# Altium schematic sheet RECEIVER DJI FPV MAIN.SchDoc("RECEIVER DJI FPV MAIN")
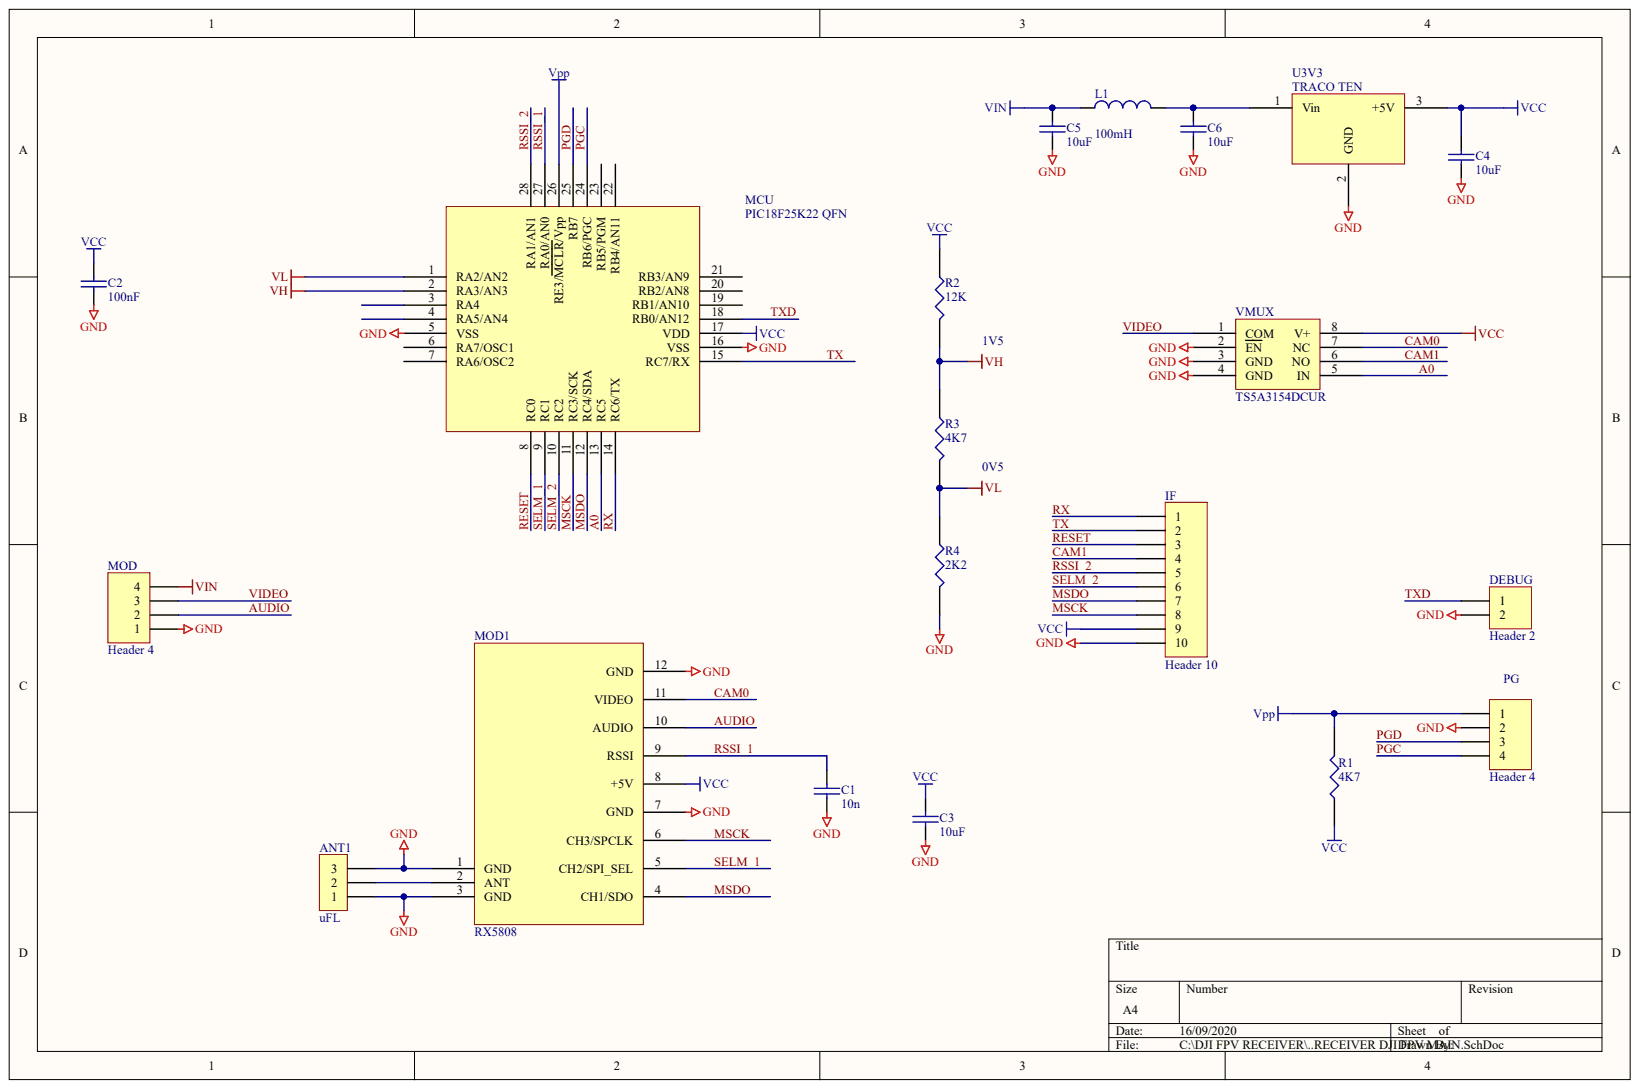
[diagram p0: RECEIVER DJI FPV MAIN.SchDoc]

## Parts
ANT1  uFL  [ufl]
C1  10n  [0805]
C2  100nF  [0805]
C3  10uF  [0805]
C4  10uF  [0805]
C5  10uF  [0805]
C6  10uF  [0805]
DEBUG  Header 2  [HDR1X2]
IF  Header 10  [ziff10]
L1  value 100mH  [0805]  (hidden comment: Inductor)
MCU  PIC18F25K22 QFN  [pic18f5k20 qfn]
MOD  Header 4  [CNB]
MOD1  RX5808  [rx5808s]
PG  Header 4  [prog4]
R1  4K7  [0805]
R2  12K  [0805]
R3  4K7  [0805]
R4  2K2  [0805]
U3V3  TRACO TEN  [murata dcdc]
VMUX  TS5A3154DCUR  [VSSOP8]

## Nets
GND: ANT1.1 ANT1.3 C1.2 C2.2 C3.2 C4.2 C5.2 C6.2 DEBUG.2 IF.10 MCU.5(VSS) MCU.16(VSS) MOD.1 MOD1.1(GND) MOD1.3(GND) MOD1.7(GND) MOD1.12(GND) PG.2 R4.2 U3V3.2 VMUX.2(EN) VMUX.3(GND) VMUX.4(GND)
VCC: C2.1 C3.1 C4.1 IF.9 MCU.17(VDD) MOD1.8(+5V) R1.1 R2.1 U3V3.3 VMUX.8(V+)
VH: MCU.2(RA3/AN3) R2.2 R3.1
VIN: C5.1 L1.1 MOD.4
VL: MCU.1(RA2/AN2) R3.2 R4.1
VPP: MCU.26(RE3/MCLR/Vpp) PG.1 R1.2
A0: MCU.13(RC5) VMUX.5(IN)
AUDIO: MOD.2 MOD1.10(AUDIO)
CAM0: MOD1.11(VIDEO) VMUX.7(NC)
CAM1: IF.4 VMUX.6(NO)
MSCK: IF.8 MCU.11(RC3/SCK) MOD1.6(CH3/SPCLK)
MSDO: IF.7 MCU.12(RC4/SDA) MOD1.4(CH1/SDO)
PGC: MCU.24(RB6/PGC) PG.4
PGD: MCU.25(RB7) PG.3
RESET: IF.3 MCU.8(RC0)
RSSI_1: C1.1 MCU.27(RA0/AN0) MOD1.9(RSSI)
RSSI_2: IF.5 MCU.28(RA1/AN1)
RX: IF.1 MCU.14(RC6/TX)
SELM_1: MCU.9(RC1) MOD1.5(CH2/SPI_SEL)
SELM_2: IF.6 MCU.10(RC2)
TX: IF.2 MCU.15(RC7/RX)
TXD: DEBUG.1 MCU.18(RB0/AN12)
VIDEO: MOD.3 VMUX.1(COM)
NetANT1_2: ANT1.2 MOD1.2(ANT)
NetC6_1: C6.1 L1.2 U3V3.1

Not connected: MCU 3(RA4) 4(RA5/AN4) 6(RA7/OSC1) 7(RA6/OSC2) 19(RB1/AN10) 20(RB2/AN8) 21(RB3/AN9) 22(RB4/AN11) 23(RB5/PGM)

## Library data of the parts
ANT1 = CON3: Connector; : 7839638; : 1.212; Component Kind: Standard; Library Name: btvtx var.IntLib
C1-C6 = CAP: Capacitor; Component Kind: Standard
DEBUG = Header 2: Header, 2-Pin; Component Kind: Standard; LatestRevisionDate: 17-Jul-2002; LatestRevisionNote: Re-released for DXP Platform.; Library Name: Miscellaneous Connectors.IntLib; Publisher: Altium Limited
IF = Header 10: Header, 10-Pin; Component Kind: Standard; LatestRevisionDate: 17-Jul-2002; LatestRevisionNote: Re-released for DXP Platform.; Library Name: Miscellaneous Connectors.IntLib; Publisher: Altium Limited
L1 = Inductor: Code_IEC: 1005; Code_JEITA: 0402; Component Kind: Standard; Description: Inductor; LatestRevisionDate: 29-May-2009; LatestRevisionNote: IPC-7351 Footprint Added.; Library Name: Miscellaneous Devices.IntLib; PackageDescription: Chip Inductor; PackageReference: 0402-A; Published: 8-Jun-2000; Simulation: INDUCTOR; Publisher: Altium Limited
MCU = PIC18F25K20 QFN: Component Kind: Standard; Library Name: btvtx var.SCHLIB
MOD PG = Header 4: Header, 4-Pin; Component Kind: Standard; LatestRevisionDate: 17-Jul-2002; LatestRevisionNote: Re-released for DXP Platform.; Library Name: Miscellaneous Connectors.IntLib; Publisher: Altium Limited
R1-R4 = RES1: Component Kind: Standard
U3V3 = MC7805T: Component Kind: Standard
MOD1 = RX5808: Component Kind: Standard
VMUX = TS5A3154DCUR: Component Kind: Standard; Library Name: DJI FPV RECEIVER.SCHLIB
